# Altium schematic sheet STM32F303CCT6_PCB.SchDoc(STM32F303CCT6_PCB)
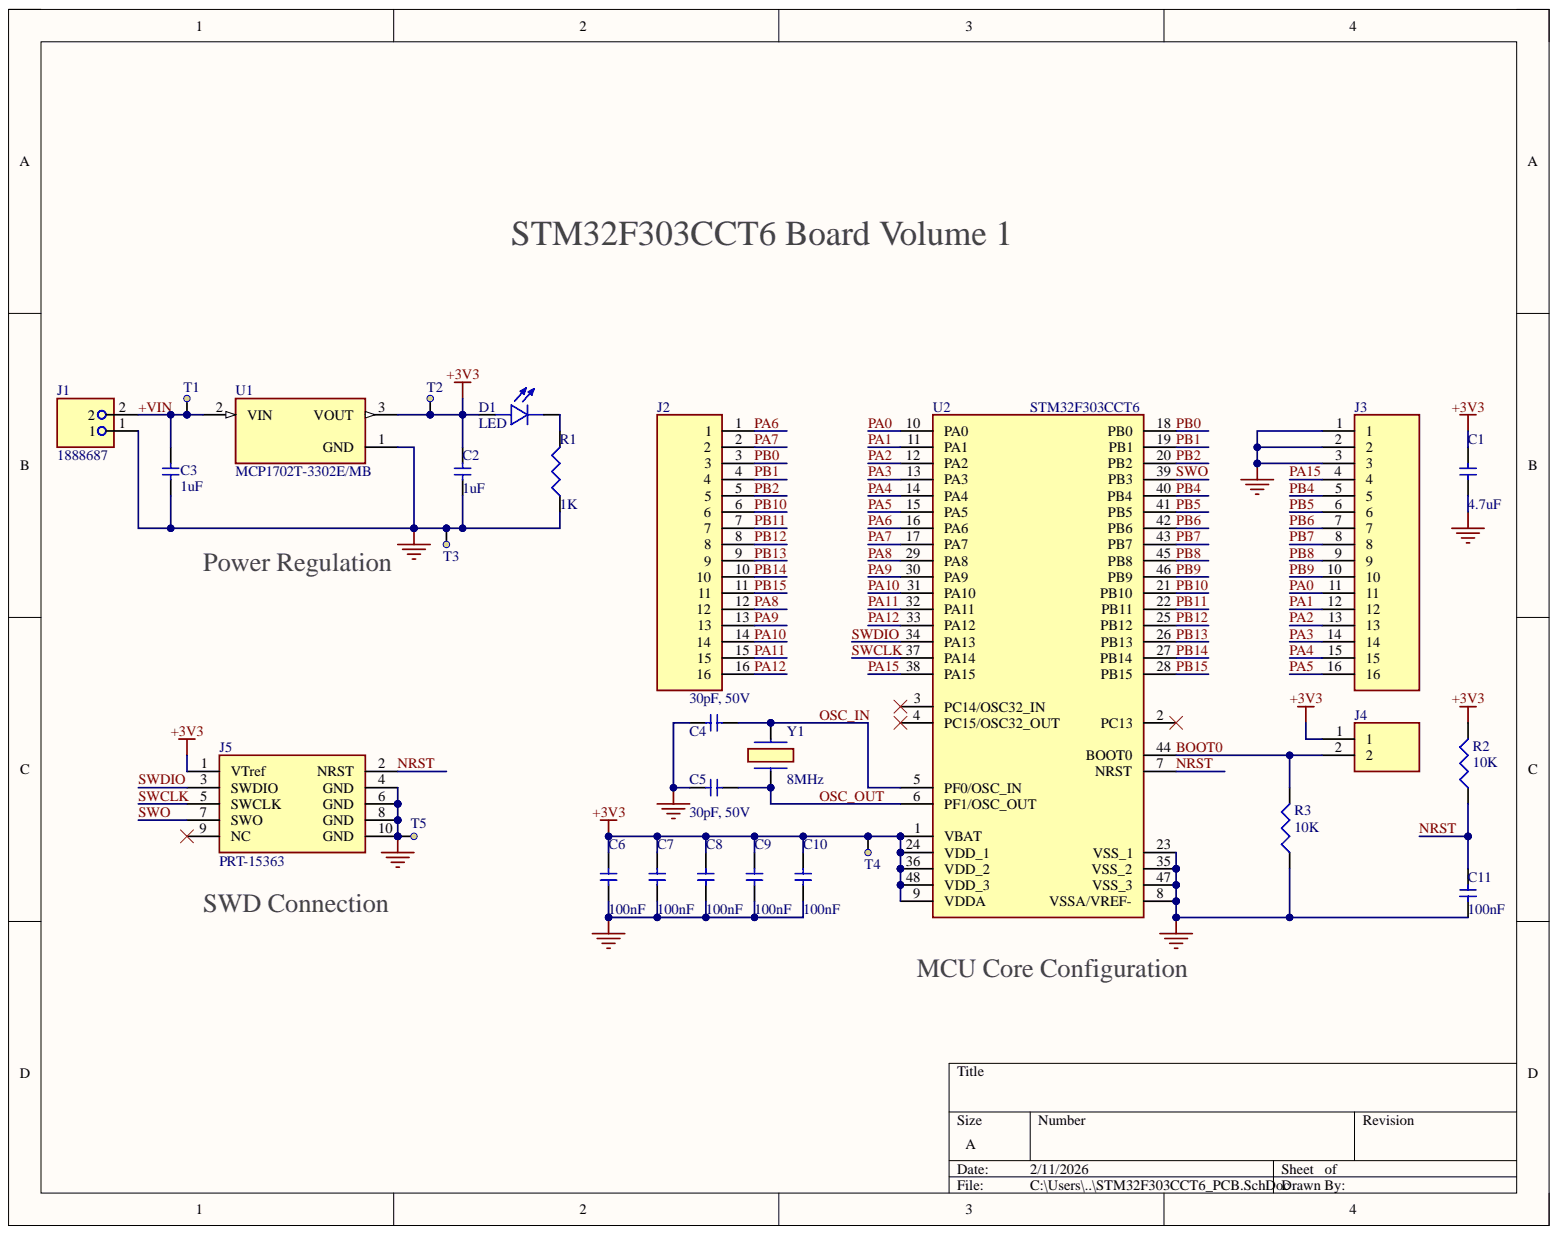
[diagram p0: STM32F303CCT6_PCB.SchDoc]

## Parts
C1  4.7uF  [C0603]
C2  1uF  [C0805]
C3  1uF  [C0805]
C4  30pF, 50V  [C0603]
C5  30pF, 50V  [C0603]
C6  100nF  [C0603]
C7  100nF  [C0603]
C8  100nF  [C0603]
C9  100nF  [C0603]
C10  100nF  [C0603]
C11  100nF  [C0603]
D1  LED  [LEDC3216X120N]
J1  1888687  [PHOENIX_1888687]
J2  68024-116HLF  [HDRV16W67P0X254_1X16_4064X241X1082P]  (value not drawn)
J3  68024-116HLF  [HDRV16W67P0X254_1X16_4064X241X1082P]  (value not drawn)
J4  M20-9990246  [HDRV2W66P0X254_1X2_508X254X864P]  (value not drawn)
J5  PRT-15363  [PRT15363]
R1  1K  [R0603]
R2  10K  [R0603]
R3  10K  [R0603]
T1  testpoint_1.5mm  [TP_smd_1.5]  (value not drawn)
T2  testpoint_1.5mm  [TP_smd_1.5]  (value not drawn)
T3  testpoint_1.5mm  [TP_smd_1.5]  (value not drawn)
T4  testpoint_1.5mm  [TP_smd_1.5]  (value not drawn)
T5  testpoint_1.5mm  [TP_smd_1.5]  (value not drawn)
U1  MCP1702T-3302E/MB  [REG_MCP1702T-3302E/MB]
U2  STM32F303CCT6  [QFP50P900X900X160-48N]
Y1  8MHz  [ABLS8000MHZB2T]

## Nets
+3V3: C1.2 C2.2 C6.2 C7.2 C8.2 C9.2 C10.2 D1.POS J4.1 J5.1(VTref) R2.2 T2.1 T4.1 U1.3 U2.1(VBAT) U2.9(VDDA) U2.24(VDD_1) U2.36(VDD_2) U2.48(VDD_3)
GND: C1.1 C2.1 C3.1 C4.2 C5.2 C6.1 C7.1 C8.1 C9.1 C10.1 C11.1 J1.1 J3.1 J3.2 J3.3 J5.4(GND) J5.6(GND) J5.8(GND) J5.10(GND) R1.1 R3.1 T3.1 T5.1 U1.1 U2.8(VSSA/VREF-) U2.23(VSS_1) U2.35(VSS_2) U2.47(VSS_3)
+VIN: C3.2 J1.2 T1.1 U1.2
BOOT0: J4.2 R3.2 U2.44(BOOT0)
NRST: C11.2 J5.2(NRST) R2.1 U2.7(NRST)
OSC_IN: C4.1 U2.5(PF0/OSC_IN) Y1.2
OSC_OUT: C5.1 U2.6(PF1/OSC_OUT) Y1.1
PA0: J3.11 U2.10(PA0)
PA1: J3.12 U2.11(PA1)
PA2: J3.13 U2.12(PA2)
PA3: J3.14 U2.13(PA3)
PA4: J3.15 U2.14(PA4)
PA5: J3.16 U2.15(PA5)
PA6: J2.1 U2.16(PA6)
PA7: J2.2 U2.17(PA7)
PA8: J2.12 U2.29(PA8)
PA9: J2.13 U2.30(PA9)
PA10: J2.14 U2.31(PA10)
PA11: J2.15 U2.32(PA11)
PA12: J2.16 U2.33(PA12)
PA15: J3.4 U2.38(PA15)
PB0: J2.3 U2.18(PB0)
PB1: J2.4 U2.19(PB1)
PB2: J2.5 U2.20(PB2)
PB4: J3.5 U2.40(PB4)
PB5: J3.6 U2.41(PB5)
PB6: J3.7 U2.42(PB6)
PB7: J3.8 U2.43(PB7)
PB8: J3.9 U2.45(PB8)
PB9: J3.10 U2.46(PB9)
PB10: J2.6 U2.21(PB10)
PB11: J2.7 U2.22(PB11)
PB12: J2.8 U2.25(PB12)
PB13: J2.9 U2.26(PB13)
PB14: J2.10 U2.27(PB14)
PB15: J2.11 U2.28(PB15)
SWCLK: J5.5(SWCLK) U2.37(PA14)
SWDIO: J5.3(SWDIO) U2.34(PA13)
SWO: J5.7(SWO) U2.39(PB3)
NetD1_NEG: D1.NEG R1.2

Not connected: J5 9(NC); U2 2(PC13) 3(PC14/OSC32_IN) 4(PC15/OSC32_OUT)

## Library data of the parts
C1 C4-C11 = C0603: Component Kind: Standard; Library Name: smdPassives.IntLib
C2 C3 = C0805: Component Kind: Standard; Library Name: smdPassives.IntLib
D1 = 1206_LED: Component Kind: Standard; Library Name: STM32F303CCT6_PCB.SchLib
J1 = 1888687: Terminal Block Connector; PCB; Screw; 2; 5.08 mm; Front; 24-14 AWG; 16 A; 250 V; Solder; Manufacturer: Phoenix Contact; Approvals: UL, VDE, cUL; Current: 10A; Current Rating: 16A; Gender: Male; Max Supply Voltage: 250V; Number of Circuits: 2; Number of Levels: 1; Pitch: 5.08mm; Voltage: 300V; Voltage Rating: 400V; Wire Gauge (Max): 30AWG; Wire Gauge (Min): 12AWG; Wire/Cable Gauge: 14AWG; Category: Terminal Blocks; China RoHS: Compliant; Color: Green; Component Kind: Standard; ComponentLink1Description: https://datasheet.octopart.com:443/1888687-Phoenix-Contact-datasheet-181090269.pdf?src-supplier=IHS; ComponentLink1URL: https://datasheet.octopart.com:443/1888687-Phoenix-Contact-datasheet-181090269.pdf?src-supplier=IHS; ComponentLink2Description: https://datasheet.octopart.com:443/1888687-Phoenix-Contact-datasheet-62122975.pdf?src-supplier=Upverter; ComponentLink2URL: https://datasheet.octopart.com:443/1888687-Phoenix-Contact-datasheet-62122975.pdf?src-supplier=Upverter; ComponentLink3Description: https://datasheet.octopart.com:443/MKDSN2%2C5-2-5.08-Phoenix-Contact-datasheet-86076178.pdf?src-supplier=Farnell; ComponentLink3URL: https://datasheet.octopart.com:443/MKDSN2%2C5-2-5.08-Phoenix-Contact-datasheet-86076178.pdf?src-supplier=Farnell; ComponentLink4Description: https://datasheet.octopart.com:443/1888687-Phoenix-Contact-datasheet-86454513.pdf?src-supplier=Newark; ComponentLink4URL: https://datasheet.octopart.com:443/1888687-Phoenix-Contact-datasheet-86454513.pdf?src-supplier=Newark; ComponentLink5Description: https://datasheet.octopart.com:443/MKDSN2%2C5-2-5.08-Phoenix-Contact-datasheet-66579443.pdf?src-supplier=element14+APAC; ComponentLink5URL: https://datasheet.octopart.com:443/MKDSN2%2C5-2-5.08-Phoenix-Contact-datasheet-66579443.pdf?src-supplier=element14+APAC; ComponentLink6Description: https://datasheet.octopart.com:443/MKDSN2%2C5/2-5.08-Phoenix-Contact-datasheet-8826921.pdf?src-supplier=element14+APAC; ComponentLink6URL: https://datasheet.octopart.com:443/MKDSN2%2C5/2-5.08-Phoenix-Contact-datasheet-8826921.pdf?src-supplier=element14+APAC; ComponentLink7Description: https://datasheet.octopart.com:443/1888687-Phoenix-Contact-datasheet-154782946.pdf?src-supplier=Electro+Sonic; ComponentLink7URL: https://datasheet.octopart.com:443/1888687-Phoenix-Contact-datasheet-154782946.pdf?src-supplier=Electro+Sonic; ComponentLink8Description: https://datasheet.octopart.com:443/1888687-Phoenix-Contact-datasheet-177361247.pdf?src-supplier=Burklin+Elektronik; ComponentLink8URL: https://datasheet.octopart.com:443/1888687-Phoenix-Contact-datasheet-177361247.pdf?src-supplier=Burklin+Elektronik; ComponentLink9Description: https://datasheet.octopart.com:443/1888687-Phoenix-Contact-datasheet-165550994.pdf?src-supplier=Burklin+Elektronik; ComponentLink9URL: https://datasheet.octopart.com:443/1888687-Phoenix-Contact-datasheet-165550994.pdf?src-supplier=Burklin+Elektronik; ComponentLink10Description: https://datasheet.octopart.com:443/1888687-Phoenix-Contact-datasheet-62053910.pdf?src-supplier=TME; ComponentLink10URL: https://datasheet.octopart.com:443/1888687-Phoenix-Contact-datasheet-62053910.pdf?src-supplier=TME; ComponentLink11Description: https://datasheet.octopart.com:443/1888687-Phoenix-Contact-datasheet-33510129.pdf?src-supplier=MRO+Stop; ComponentLink11URL: https://datasheet.octopart.com:443/1888687-Phoenix-Contact-datasheet-33510129.pdf?src-supplier=MRO+Stop; ComponentLink12Description: https://datasheet.octopart.com:443/1888687-Phoenix-Contact-datasheet-11892468.pdf?src-supplier=Arrow+Electronics; ComponentLink12URL: https://datasheet.octopart.com:443/1888687-Phoenix-Contact-datasheet-11892468.pdf?src-supplier=Arrow+Electronics; ComponentLink13Description: https://datasheet.octopart.com:443/1888687-Phoenix-Contact-datasheet-9680877.pdf?src-supplier=RS+(Formerly+Allied+Electronics); ComponentLink13URL: https://datasheet.octopart.com:443/1888687-Phoenix-Contact-datasheet-9680877.pdf?src-supplier=RS+(Formerly+Allied+Electronics); ComponentLink14Description: https://datasheet.octopart.com:443/1888687-Phoenix-Contact-datasheet-75182.pdf?src-supplier=RS+(Formerly+Allied+Electronics); ComponentLink14URL: https://datasheet.octopart.com:443/1888687-Phoenix-Contact-datasheet-75182.pdf?src-supplier=RS+(Formerly+Allied+Electronics); Connector Type: Screw; Contact Material: Tin; Contact Plating: Tin; Depth: 9.5mm; Flammability Rating: UL94 V-0; Height: 15.15mm; Housing Color: Green; Housing Material: Polyamide; Lead Free: Lead Free; Library Name: STM32F303CCT6_PCB.SchLib; Lifecycle Status: Production; Manufacturer Part Number: 1888687; Material: Polyamide; Max Current Rating: 16A; Min Operating Temperature: -40°C; Mounting Technology: Screw; Number of Contacts: 2; Number of Poles: 2; Number of Positions: 2; Number of Positions Per Level: 2; Number of Rows: 1; Orientation: Straight; Pins: 2; Pricing: 1=1.24, 10=1.24, 50=1.24, 100=1.24, 1000=1.24, 10000=1.24 (USD); REACH SVHC: Yes; RoHS: Compliant; Stock: 1235; Supplier: Mouser; Supplier Part Number: 651-1888687; Termination: Screw; Voltage Rating (AC): 250V; Weight: 3.259997g; Width: 9.5mm
J2 J3 = 68024-116HLF: Conn Unshrouded Header HDR 16 POS 2.54mm Solder ST Top Entry Thru-Hole BergStik® Bulk; Manufacturer: Amphenol Communications Solutions; Connector Type: Male Pin; Current Rating: 3A; Gender: Male; Insulation Resistance: 5GΩ; Lead Length: 3.81mm; Mating Post Length: 8.08mm; Number of Contacts: 16; Voltage Rating: 1.5kV; Category: Card Edge Connectors; Color: Black; Component Kind: Standard; ComponentLink1Description: https://datasheet.octopart.com:443/68024-116HLF-Amphenol-Communications-Solutions-datasheet-151206381.pdf?src-supplier=IHS; ComponentLink1URL: https://datasheet.octopart.com:443/68024-116HLF-Amphenol-Communications-Solutions-datasheet-151206381.pdf?src-supplier=IHS; ComponentLink2Description: https://datasheet.octopart.com:443/68024-116HLF-Amphenol-Communications-Solutions-datasheet-41232361.pdf?src-supplier=Newark; ComponentLink2URL: https://datasheet.octopart.com:443/68024-116HLF-Amphenol-Communications-Solutions-datasheet-41232361.pdf?src-supplier=Newark; Contact Gender: Male; Contact Material: Bronze; Contact Mating Length: 8.0772mm; Contact Plating: GXT, Gold; Flammability Rating: UL94 V-0; Housing Material: Thermoplastic; Library Name: STM32F303CCT6_PCB.SchLib; Lifecycle Status: Production; Manufacturer Part Number: 68024-116HLF; Max Operating Temperature: 130°C; Min Operating Temperature: -65°C; Mounting Technology: Through Hole; Number of Positions: 16; Number of Rows: 1; Pins: 16; Pitch: 2.54mm; Pricing: 1=1.54, 10=1.26, 50=1.22, 100=1.18, 1000=0.933, 10000=0.886 (USD); REACH SVHC: Yes; RoHS: Compliant; Stock: 3066; Supplier: Mouser; Supplier Part Number: 649-68024-116HLF; Terminal Pitch: 2.54mm; Termination: Solder
J4 = M20-9990246: 2.54mm (0.1") Pitch SIL Vertical PC Tail Pin Header, 6.1mm mating pin height, tin, 2 contacts; Manufacturer: Harwin; Connector Type: Male Pin; Contact Resistance: 30mΩ; Current Rating: 3A; Dielectric Material: Polyamide; Gender: Male; Insulation Resistance: 1GΩ; Number of Contacts: 2; Voltage Rating (AC): 750V; Case/Package: SIL; Category: Headers and Wire Housings; Color: Black; Component Kind: Standard; ComponentLink1Description: https://datasheet.octopart.com:443/M20-9990246-Harwin-datasheet-169407857.pdf?src-supplier=Newark; ComponentLink1URL: https://datasheet.octopart.com:443/M20-9990246-Harwin-datasheet-169407857.pdf?src-supplier=Newark; ComponentLink2Description: https://datasheet.octopart.com:443/M20-9990246-Harwin-datasheet-27378916.pdf?src-supplier=Newark; ComponentLink2URL: https://datasheet.octopart.com:443/M20-9990246-Harwin-datasheet-27378916.pdf?src-supplier=Newark; ComponentLink3Description: https://datasheet.octopart.com:443/M20-9990246-Harwin-datasheet-96624206.pdf?src-supplier=element14+APAC; ComponentLink3URL: https://datasheet.octopart.com:443/M20-9990246-Harwin-datasheet-96624206.pdf?src-supplier=element14+APAC; ComponentLink4Description: https://datasheet.octopart.com:443/M20-9990246-Harwin-datasheet-32864541.pdf?src-supplier=Farnell; ComponentLink4URL: https://datasheet.octopart.com:443/M20-9990246-Harwin-datasheet-32864541.pdf?src-supplier=Farnell; ComponentLink5Description: https://datasheet.octopart.com:443/M20-9990246-Harwin-datasheet-7538443.pdf?src-supplier=Farnell; ComponentLink5URL: https://datasheet.octopart.com:443/M20-9990246-Harwin-datasheet-7538443.pdf?src-supplier=Farnell; ComponentLink6Description: https://datasheet.octopart.com:443/M20-9990246-Harwin-datasheet-508868.pdf?src-supplier=Farnell; ComponentLink6URL: https://datasheet.octopart.com:443/M20-9990246-Harwin-datasheet-508868.pdf?src-supplier=Farnell; Contact Gender: Male; Contact Material: Brass; Contact Mating Length: 6.096mm; Contact Plating: Tin; Country of Origin: Taiwan; Depth: 2.54mm; Export Control Classification Number (ECCN) Code: EAR99; Harmonized Tariff Schedule (HTS) Code: 8536.69.40.40; Height: 8.99mm; Housing Color: Black; Housing Material: Nylon; Introduction Date: 2005-06-27; Lead Pitch: 2.54mm; Length: 5.08mm; Library Name: STM32F303CCT6_PCB.SchLib; Lifecycle Status: Production; Manufacturer Part Number: M20-9990246; Max Current Rating: 3A; Max Operating Temperature: 105°C; Min Operating Temperature: -40°C; Mounting Technology: Through Hole; Number of Positions: 2; Number of Rows: 1; Orientation: Straight; Packaging: Bulk; Pins: 2; Pitch: 2.54mm; Pricing: 1=0.1, 10=0.079, 50=0.079, 100=0.067, 1000=0.057, 10000=0.048 (USD); Radiation Hardening: No; REACH SVHC: Yes; RoHS: Compliant; Stock: 30487; Supplier: Mouser; Supplier Part Number: 855-M20-9990246; Terminal Pitch: 2.54mm; Termination: Solder
J5 = PRT-15363: Headers & Wire Housings Header - 2x5 Pin (Female, 1.27mm); Manufacturer: SparkFun; Category: Headers and Wire Housings; Component Kind: Standard; Library Name: STM32F303CCT6_PCB.SchLib; Manufacturer Part Number: PRT-15363; Pricing: 1=1.88, 10=1.88, 50=1.88, 100=1.88, 1000=1.88, 10000=1.88 (USD); Stock: 20; Supplier: Mouser; Supplier Part Number: 474-PRT-15363
R1-R3 = R0603: Component Kind: Standard; Library Name: smdPassives.IntLib
U1 = MCP1702T-3302E/MB: 44dB@(100Hz) 250mA Fixed 3.3V Positive 13.2V SOT-89-3 Linear Voltage Regulators (LDO) ROHS; Manufacturer: Microchip; Accuracy: 2%; Dropout Voltage: 750mV; Input Bias Current: 2µA; Max Junction Temperature (Tj): 125°C; Min Input Voltage: 2.7V; Number of Channels: 1; Quiescent Current: 5µA; Case/Package: SOT-89; Category: LDO Voltage Regulators; Component Kind: Standard; ComponentLink1Description: https://datasheet.octopart.com:443/MCP1702T-3302E/MB-Microchip-datasheet-10060743.pdf?src-supplier=LKR; ComponentLink1URL: https://datasheet.octopart.com:443/MCP1702T-3302E/MB-Microchip-datasheet-10060743.pdf?src-supplier=LKR; ComponentLink2Description: https://datasheet.octopart.com:443/MCP1702T-3302E-MB-Microchip-datasheet-175858685.pdf?src-supplier=Microchip; ComponentLink2URL: https://datasheet.octopart.com:443/MCP1702T-3302E-MB-Microchip-datasheet-175858685.pdf?src-supplier=Microchip; ComponentLink3Description: https://datasheet.octopart.com:443/MCP1702T-3302E/MB-Microchip-datasheet-5325049.pdf?src-supplier=Newark; ComponentLink3URL: https://datasheet.octopart.com:443/MCP1702T-3302E/MB-Microchip-datasheet-5325049.pdf?src-supplier=Newark; ComponentLink4Description: https://datasheet.octopart.com:443/MCP1702T-3302E/MB-Microchip-datasheet-15716.pdf?src-supplier=TME; ComponentLink4URL: https://datasheet.octopart.com:443/MCP1702T-3302E/MB-Microchip-datasheet-15716.pdf?src-supplier=TME; ComponentLink5Description: https://datasheet.octopart.com:443/MCP1702T-3302E-MB-Microchip-datasheet-142675560.pdf?src-supplier=TME; ComponentLink5URL: https://datasheet.octopart.com:443/MCP1702T-3302E-MB-Microchip-datasheet-142675560.pdf?src-supplier=TME; ComponentLink6Description: https://datasheet.octopart.com:443/MCP1702T-3302E-MB-Microchip-datasheet-141094228.pdf?src-supplier=TME; ComponentLink6URL: https://datasheet.octopart.com:443/MCP1702T-3302E-MB-Microchip-datasheet-141094228.pdf?src-supplier=TME; ComponentLink7Description: https://datasheet.octopart.com:443/MCP1702T-3302E-MB-Microchip-datasheet-17900278.pdf?src-supplier=Electro+Sonic; ComponentLink7URL: https://datasheet.octopart.com:443/MCP1702T-3302E-MB-Microchip-datasheet-17900278.pdf?src-supplier=Electro+Sonic; ComponentLink8Description: https://datasheet.octopart.com:443/MCP1702T-3302E/MB-Microchip-datasheet-8324231.pdf?src-supplier=Future+Electronics; ComponentLink8URL: https://datasheet.octopart.com:443/MCP1702T-3302E/MB-Microchip-datasheet-8324231.pdf?src-supplier=Future+Electronics; ComponentLink9Description: https://datasheet.octopart.com:443/MCP1702T-3302E/MB-Microchip-datasheet-14172058.pdf?src-supplier=iiiC; ComponentLink9URL: https://datasheet.octopart.com:443/MCP1702T-3302E/MB-Microchip-datasheet-14172058.pdf?src-supplier=iiiC; ComponentLink10Description: http://sigma.octopart.com:80/188452060/materials_sheet/Microchip-MCP1702T-3302E-MB.pdf?src-supplier=Compliance+Statements; ComponentLink10URL: http://sigma.octopart.com:80/188452060/materials_sheet/Microchip-MCP1702T-3302E-MB.pdf?src-supplier=Compliance+Statements; ComponentLink11Description: http://sigma.octopart.com:80/rohs_statement/Microchip-company-71.pdf?src-supplier=Compliance+Statements; ComponentLink11URL: http://sigma.octopart.com:80/rohs_statement/Microchip-company-71.pdf?src-supplier=Compliance+Statements; ComponentLink12Description: http://sigma.octopart.com:80/reach_statement/Microchip-company-70.pdf?src-supplier=Compliance+Statements; ComponentLink12URL: http://sigma.octopart.com:80/reach_statement/Microchip-company-70.pdf?src-supplier=Compliance+Statements; ComponentLink13Description: http://sigma.octopart.com:80/conflict_mineral_statement/Microchip-company-69.pdf?src-supplier=Compliance+Statements; ComponentLink13URL: http://sigma.octopart.com:80/conflict_mineral_statement/Microchip-company-69.pdf?src-supplier=Compliance+Statements; ComponentLink14Description: http://sigma.octopart.com:80/conflict_mineral_statement/Microchip-company-68.pdf?src-supplier=Compliance+Statements; ComponentLink14URL: http://sigma.octopart.com:80/conflict_mineral_statement/Microchip-company-68.pdf?src-supplier=Compliance+Statements; Country of Assembly: China, Taiwan; Country of Fabrication: United States of America; Country of Origin: China, Taiwan; Export Control Classification Number (ECCN) Code: EAR99; Harmonized Tariff Schedule (HTS) Code: 8542.39.0060; Height: 1.6mm; Introduction Date: 2006-09-28; Lead Free: Lead Free; Length: 4.6mm; Library Name: STM32F303CCT6_PCB.SchLib; Lifecycle Status: Production; Manufacturer Part Number: MCP1702T-3302E/MB; Max Operating Temperature: 125°C; Max Output current: 250mA; Max Output Voltage: 3.3V; Max Supply Voltage: 13.2V; Min Operating Temperature: -40°C; Min Output Voltage: 3.3V; Min Supply Voltage: 2.7V; Mounting Technology: Surface Mount; Nominal Output Voltage: 3.3V; Nominal Supply Current: 2µA; Number of Outputs: 1; Number of Regulators: 1; Output Current: 250mA; Output Type: Fixed; Output Voltage: 3.3V; Output Voltage Accuracy: 2%; Packaging: Cut Tape; Pins: 3; Polarity: Positive; Pricing: 1=0.65, 10=0.65, 25=0.464, 50=0.54, 100=0.49, 1000=0.49, 10000=0.49 (USD); Projected EOL Date: 2034-09-28; REACH SVHC: No; RoHS: Compliant; Schedule B: 8542390000, 8542390000|8542390000|8542390000|8542390000|8542390000; Stock: 13290; Supplier: Mouser; Supplier Part Number: 579-MCP1702T3302E/MB; Termination: SMD/SMT; Width: 2.29mm
U2 = STM32F303CCT6: MCU 32-bit ARM Cortex M4 RISC 256KB Flash 2.5V/3.3V 48-Pin LQFP Frame; Manufacturer: STMicroelectronics; Manufacturer Package Identifier: 5B_ME; ADC Channels (Resolution): 15-Ch 12-Bit; Clock Frequency: 32MHz; Core Architecture: ARM Cortex-M4; DAC Channels (Resolution): 2-Ch 12-Bit; Data Bus Width: 32b; EEPROM Memory Size: 0B; Frequency: 72MHz; Interface: CAN, IrDA, LIN, USB; Max Supply Current: 67.6mA; Max Supply Voltage: 3.6V; Min Supply Voltage: 2V; Nominal Supply Current: 1.5µA; Number of A/D Converters: 4; Number of Channels: 15; Number of D/A Converters: 2; Number of I/Os: 37; Number of Programmable I/O: 27; Number of SPI Channels: 3; Number of Timers/Counters: 1; Operating Supply Voltage: 3.3V; Oscillator Type: Internal; Peripherals: POR; RAM Size: 40kB; Watchdog Timer: Yes; Case/Package: LQFP; Category: Microcontrollers; China RoHS: Compliant; Component Kind: Standard; ComponentLink1Description: https://datasheet.octopart.com:443/STM32F303CCT6-STMicroelectronics-datasheet-140259840.pdf?src-supplier=IHS; ComponentLink1URL: https://datasheet.octopart.com:443/STM32F303CCT6-STMicroelectronics-datasheet-140259840.pdf?src-supplier=IHS; ComponentLink2Description: https://datasheet.octopart.com:443/STM32F303CCT6-STMicroelectronics-datasheet-13725529.pdf?src-supplier=Upverter; ComponentLink2URL: https://datasheet.octopart.com:443/STM32F303CCT6-STMicroelectronics-datasheet-13725529.pdf?src-supplier=Upverter; ComponentLink3Description: https://datasheet.octopart.com:443/STM32F303CCT6-STMicroelectronics-datasheet-13237027.pdf?src-supplier=Upverter; ComponentLink3URL: https://datasheet.octopart.com:443/STM32F303CCT6-STMicroelectronics-datasheet-13237027.pdf?src-supplier=Upverter; ComponentLink4Description: https://datasheet.octopart.com:443/STM32F303CCT6-STMicroelectronics-datasheet-62336700.pdf?src-supplier=SHENGYU+ELECTRONICS; ComponentLink4URL: https://datasheet.octopart.com:443/STM32F303CCT6-STMicroelectronics-datasheet-62336700.pdf?src-supplier=SHENGYU+ELECTRONICS; ComponentLink5Description: https://datasheet.octopart.com:443/STM32F303CCT6-STMicroelectronics-datasheet-62069528.pdf?src-supplier=Newark; ComponentLink5URL: https://datasheet.octopart.com:443/STM32F303CCT6-STMicroelectronics-datasheet-62069528.pdf?src-supplier=Newark; ComponentLink6Description: https://datasheet.octopart.com:443/STM32F303CCT6-STMicroelectronics-datasheet-27203478.pdf?src-supplier=Newark; ComponentLink6URL: https://datasheet.octopart.com:443/STM32F303CCT6-STMicroelectronics-datasheet-27203478.pdf?src-supplier=Newark; ComponentLink7Description: https://datasheet.octopart.com:443/STM32F303CCT6-STMicroelectronics-datasheet-21407653.pdf?src-supplier=Newark; ComponentLink7URL: https://datasheet.octopart.com:443/STM32F303CCT6-STMicroelectronics-datasheet-21407653.pdf?src-supplier=Newark; ComponentLink8Description: https://datasheet.octopart.com:443/STM32F303CCT6-STMicroelectronics-datasheet-12357413.pdf?src-supplier=Farnell; ComponentLink8URL: https://datasheet.octopart.com:443/STM32F303CCT6-STMicroelectronics-datasheet-12357413.pdf?src-supplier=Farnell; Contact Plating: Gold; Flash Memory Size: 256kB; Height: 1.45mm; Height - Seated (Max): 1.6mm; Lead Free: Lead Free; Length: 7mm; Library Name: STM32F303CCT6_PCB.SchLib; Lifecycle Status: Production; Manufacturer Part Number: STM32F303CCT6; Max Operating Temperature: 85°C; Memory Format: FLASH; Memory Size: 256kB; Min Operating Temperature: -40°C; Min Supply Voltage (DC): 2V; Number of I2C Channels: 2; Number of Terminals: 48; Number of USART Channels: 3; Number of USB Channels: 1; Pins: 48; Pricing: 1=6.93, 10=5.48, 50=5.12, 100=4.96, 1000=4.49, 10000=4.49 (USD); Radiation Hardening: No; REACH SVHC: Yes; RoHS: Compliant; Schedule B: 8542310000; Stock: 2385; Supplier: Mouser; Supplier Part Number: 511-STM32F303CCT6; Terminal Pitch: 500µm; Width: 7mm
Y1 = ABLS-8.000MHZ-B2-T: Crystal 8MHz ±20ppm (Tol) ±50ppm (Stability) 18pF FUND 80Ohm 2-Pin HC-49/US SMD T/R; Manufacturer: Abracon; Accuracy: 0.002%; ESR: 80Ω; Case/Package: SMD/SMT; Category: Crystals; China RoHS: Compliant; Component Kind: Standard; ComponentLink1Description: https://datasheet.octopart.com:443/ABLS-8.000MHZ-B-2-T-Abracon-datasheet-182204513.pdf?src-supplier=IHS; ComponentLink1URL: https://datasheet.octopart.com:443/ABLS-8.000MHZ-B-2-T-Abracon-datasheet-182204513.pdf?src-supplier=IHS; ComponentLink2Description: https://datasheet.octopart.com:443/ABLS-8.000MHZ-B2-T-Abracon-datasheet-37142362.pdf?src-supplier=IHS; ComponentLink2URL: https://datasheet.octopart.com:443/ABLS-8.000MHZ-B2-T-Abracon-datasheet-37142362.pdf?src-supplier=IHS; ComponentLink3Description: https://datasheet.octopart.com:443/ABLS-8.000MHZ-B2-T-Abracon-datasheet-10208858.pdf?src-supplier=Sager+Electronics; ComponentLink3URL: https://datasheet.octopart.com:443/ABLS-8.000MHZ-B2-T-Abracon-datasheet-10208858.pdf?src-supplier=Sager+Electronics; ComponentLink4Description: https://datasheet.octopart.com:443/ABLS-8.000MHZ-B2-T-Abracon-datasheet-8821566.pdf?src-supplier=Sager+Electronics; ComponentLink4URL: https://datasheet.octopart.com:443/ABLS-8.000MHZ-B2-T-Abracon-datasheet-8821566.pdf?src-supplier=Sager+Electronics; ComponentLink5Description: https://datasheet.octopart.com:443/ABLS-8.000MHZ-B2-T-Abracon-datasheet-8445777.pdf?src-supplier=Sager+Electronics; ComponentLink5URL: https://datasheet.octopart.com:443/ABLS-8.000MHZ-B2-T-Abracon-datasheet-8445777.pdf?src-supplier=Sager+Electronics; ComponentLink6Description: https://datasheet.octopart.com:443/ABLS-8.000MHZ-B2-T-Abracon-datasheet-8324655.pdf?src-supplier=Sager+Electronics; ComponentLink6URL: https://datasheet.octopart.com:443/ABLS-8.000MHZ-B2-T-Abracon-datasheet-8324655.pdf?src-supplier=Sager+Electronics; ComponentLink7Description: https://datasheet.octopart.com:443/ABLS-8.000MHZ-B2-T-Abracon-datasheet-5314488.pdf?src-supplier=Sager+Electronics; ComponentLink7URL: https://datasheet.octopart.com:443/ABLS-8.000MHZ-B2-T-Abracon-datasheet-5314488.pdf?src-supplier=Sager+Electronics; ComponentLink8Description: https://datasheet.octopart.com:443/ABLS-8.000MHZ-B2-T-Abracon-datasheet-607.pdf?src-supplier=RS+(Formerly+Allied+Electronics); ComponentLink8URL: https://datasheet.octopart.com:443/ABLS-8.000MHZ-B2-T-Abracon-datasheet-607.pdf?src-supplier=RS+(Formerly+Allied+Electronics); ComponentLink9Description: https://datasheet.octopart.com:443/ABLS-8.000MHZ-B2-T-Abracon-datasheet-139816269.pdf?src-supplier=JRH+Electronics; ComponentLink9URL: https://datasheet.octopart.com:443/ABLS-8.000MHZ-B2-T-Abracon-datasheet-139816269.pdf?src-supplier=JRH+Electronics; ComponentLink10Description: https://sigma.octopart.com:443/141159843/technical_drawing/Abracon-ABLS-8.000MHZ-B2-T.pdf?src-supplier=Abracon+SCT; ComponentLink10URL: https://sigma.octopart.com:443/141159843/technical_drawing/Abracon-ABLS-8.000MHZ-B2-T.pdf?src-supplier=Abracon+SCT; ComponentLink11Description: http://sigma.octopart.com:80/conflict_mineral_statement/Abracon-company-27.pdf?src-supplier=Compliance+Statements; ComponentLink11URL: http://sigma.octopart.com:80/conflict_mineral_statement/Abracon-company-27.pdf?src-supplier=Compliance+Statements; Contact Plating: Tin; Depth: 4.7mm; ESR (Equivalent Series Resistance): 80Ω; Frequency: 8MHz; Frequency Stability: 50ppm; Frequency Tolerance: 0.002%; Height: 4.2mm; Lead Free: Lead Free; Length: 11.4mm; Library Name: STM32F303CCT6_PCB.SchLib; Lifecycle Status: Production; Load Capacitance: 18pF; Manufacturer Part Number: ABLS-8.000MHZ-B2-T; Max Operating Temperature: 70°C; Min Operating Temperature: -20°C; Mounting Technology: Surface Mount; Packaging: Cut Tape; Pins: 2; Pricing: 1=0.27, 10=0.237, 50=0.213, 100=0.204, 1000=0.176, 10000=0.144 (USD); Radiation Hardening: No; REACH SVHC: Yes; RoHS: Compliant; Schedule B: 8541600025, 8541600025|8541600025, 8541600025|8541600025|8541600025, 8541600025|8541600025|8541600025|8541600025; Stock: 66422; Supplier: Mouser; Supplier Part Number: 815-ABLS-8-B2; Termination: SMD/SMT; Tolerance: 0.002%; Width: 4.7mm
T1-T5 = testpoint_1.5mm: Component Kind: Standard; Library Name: STM32F303CCT6_PCB.SchLib
